# Altium schematic sheet UART.SchDoc
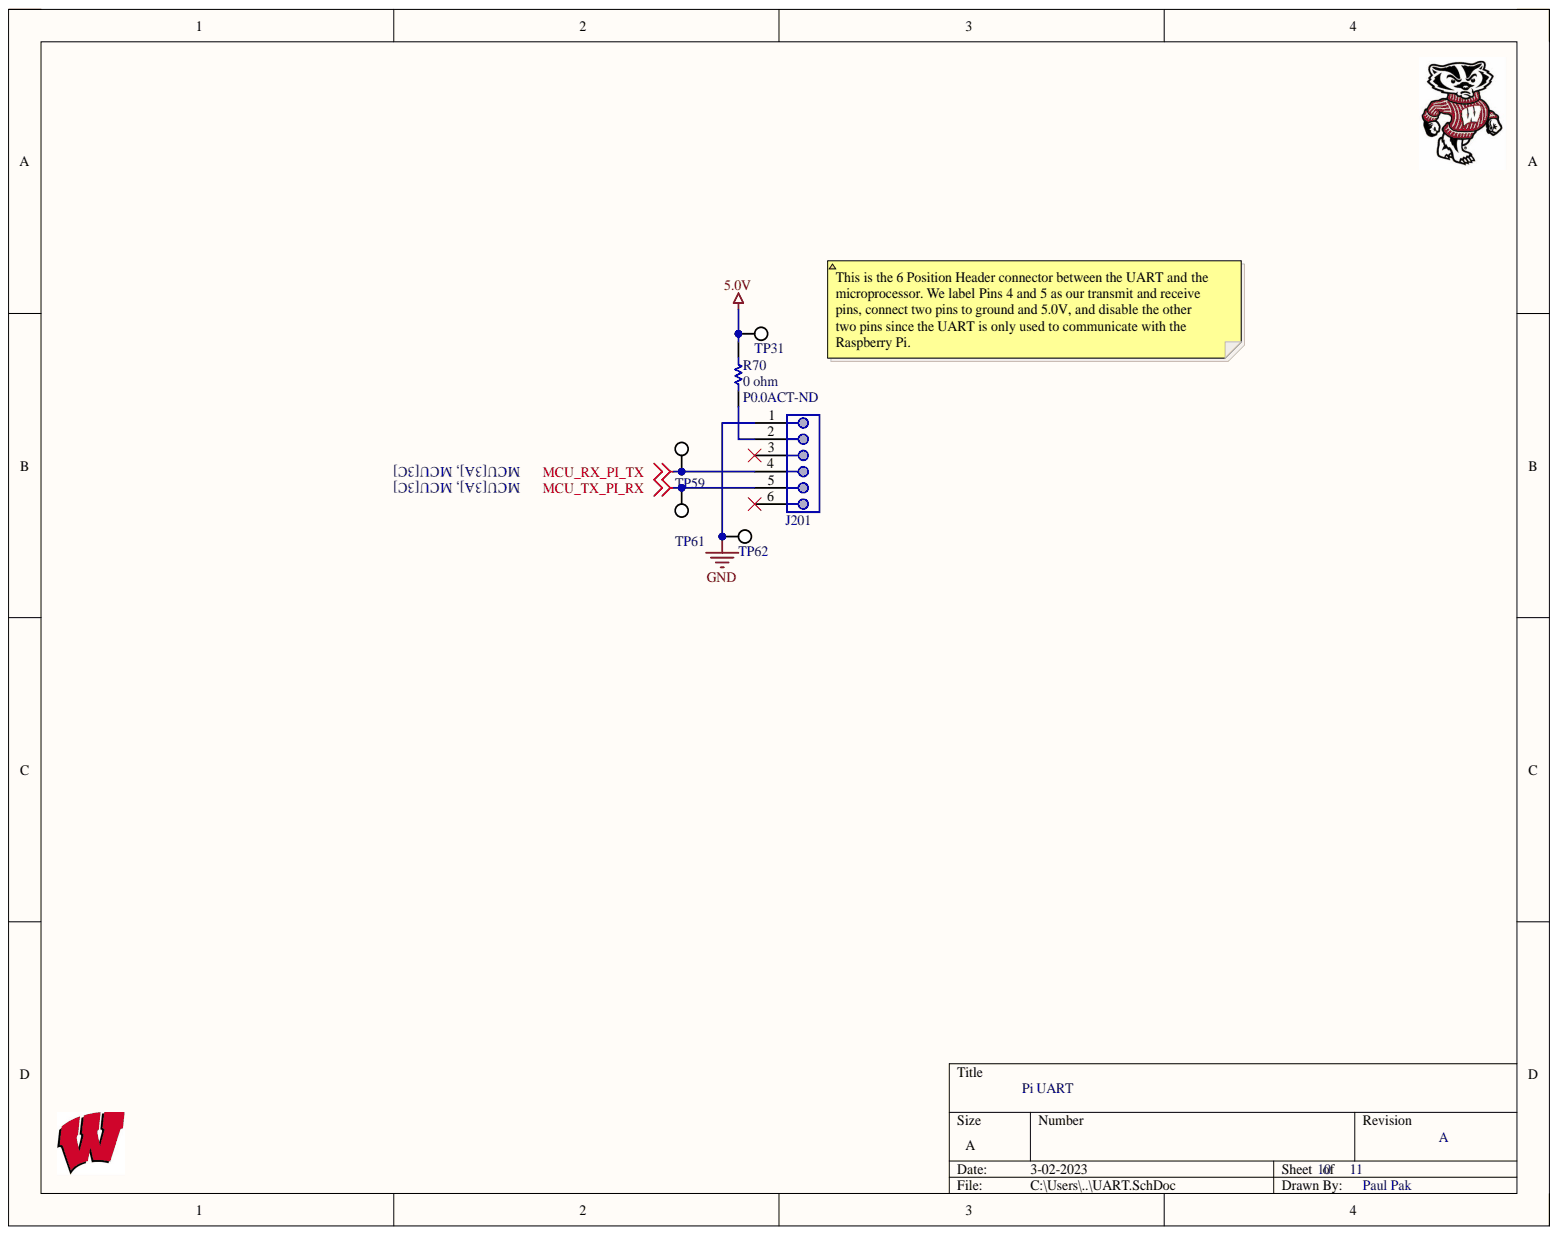
[diagram p0: UART.SchDoc]

## Parts
J201  (no value)  [HDR1X6]
R70  0 ohm  Supplier Part Number 1: P0.0ACT-ND  [RESC2013X70X40NL20T20]
TP31  5019  [KSTN-5019_V]  (value not drawn)
TP59  5019  [KSTN-5019_V]  (value not drawn)
TP61  5019  [KSTN-5019_V]  (value not drawn)
TP62  5019  [KSTN-5019_V]  (value not drawn)

## Nets
5.0V: R70.2 TP31.1
GND: J201.1 TP62.1
MCU_RX_PI_TX: J201.4 TP59.1
MCU_TX_PI_RX: J201.5 TP61.1
NetJ201_2: J201.2 R70.1

Not connected: J201 3 6

## Library data of the parts
J201 = CONN_HEADER_MALE_1x6: Female, 6C, Rt Angle, .100"CC; 3.15mm Tail, No Mounting; PBT, Phos. Bronze, 105 C; Manufacturer 1: Sullins; Manufacturer Part Number 1: PPPC061LGBN-RC; Connector Type: Header; Number of Positions: 6; Pitch: 0.1inch; Category: Connectors; Color: Black; Component Kind: Standard; ComponentLink1Description: https://media.digikey.com/pdf/Data%20Sheets/Sullins%20PDFs/Female_Headers.100_DS.pdf?src-supplier=Digi-Key; ComponentLink1URL: https://media.digikey.com/pdf/Data%20Sheets/Sullins%20PDFs/Female_Headers.100_DS.pdf?src-supplier=Digi-Key; ComponentLink2Description: http://www.sullinscorp.com/catalogs/78_Page80-81_HEADER_.100_2.54mm_FEMALE.pdf?src-supplier=Future+Electronics; ComponentLink2URL: http://www.sullinscorp.com/catalogs/78_Page80-81_HEADER_.100_2.54mm_FEMALE.pdf?src-supplier=Future+Electronics; Contact Material: Bronze; Contact Plating: Gold; Contact Type: Female Socket; Height: 2.4mm; Housing Color: Black; Housing Material: Plastic; Lead Free: Lead Free; Length: 15.7mm; Library Name: Connectors.SchLib; Mount: Through Hole; Number of Rows: 1; Package Quantity: 1000; Packaging: Bulk; Pricing 1: 1=0.66000, 10=0.56000, 80=0.50288, 440=0.41148, 1000=0.36576, 5000=0.33020 (USD); RoHS: Yes; RoHS Compliant: Yes; Stock 1: 30480; Supplier 1: Digi-Key; Supplier Part Number 1: S5481-ND; Termination: Solder; Width: 8.5mm
R70 = CMP-1665-00002-5: Chip Resistor, 0 Ohm, +/- 1%, 0.125 W, -55 to 155 degC, 0805 (2012 Metric), RoHS, Tape and Reel; Manufacturer: Panasonic; Manufacturer Part Number: ERJ-6GEY0R00V; Current Rating: 2A; Max Power Dissipation: 125mW; Temperature Coefficient: 600ppm/°C; Tolerance: 5%; Value: 0R; Voltage Rating: 150V; Case Code (Imperial): 0805; Case Code (Metric): 2012; Case/Package: 0805; Category: Chip SMD Resistors; Component Kind: Standard; ComponentLink1Description: Manufacturer URL; ComponentLink1URL: http://www.panasonic.com/; ComponentLink2Description: Datasheet; ComponentLink2URL: http://industrial.panasonic.com/cdbs/www-data/pdf/RDA0000/AOA0000C84.pdf; Construction: Rectangular; Contact Plating: Tin; DatasheetVersion: 03/2015; Depth: 1.25mm; Features: Automotive AEC-Q200; Height: 0.6 mm; Lead Free: Lead Free; Length: 2 mm; Library Name: Altium Content Vault; Max Operating Temperature: 155 degC; Military Standard: Not; Min Operating Temperature: -55 degC; Mounting Technology: Surface Mount; Number of Terminations: 2; Package Quantity: 5000; PackageDescription: 2-Pin Surface Mount Device, Body 2 x 1.25 mm; Packaging: Tape and Reel; PartNumber: ERJ-6GEY0R00V; Power Rating: 125mW; Pricing 1: 1=0.10000, 10=0.06400, 25=0.04680, 50=0.03560, 100=0.02620, 250=0.02000, 500=0.01598, 1000=0.01177 (USD); Radiation Hardening: No; Ratings: AEC-Q200; REACH SVHC: No SVHC; Reach SVHC Compliant: Yes; Resistance: 0 Ohm; Resistor Type: Thick Film; RoHS: Yes; RoHS Compliant: Yes; RoHSCompliant: True; Series: ERJ; Stock 1: 3172332; Supplier 1: Digi-Key; Technology: THICK FILM; Termination: SMD/SMT; Voltage Rating (DC): 150V; Width: 1.25 mm
TP31 TP59 TP61 TP62 = CMP-1672-00008-1: Test Point, 1 Position SMD, RoHS, Tape and Reel; Manufacturer: Keystone Electronics; Body Material: Bronze; Plating: Silver; Category: Connectors; Component Kind: Standard; ComponentLink1Description: Manufacturer URL; ComponentLink1URL: http://www.keyelco.com/; ComponentLink2Description: Datasheet; ComponentLink2URL: http://www.keyelco.com/product-pdf.cfm?p=1357; ComponentLink3Description: https://datasheet.ciiva.com/16885/70182998-16885945.pdf?src-supplier=Allied+Electronics; ComponentLink3URL: https://datasheet.ciiva.com/16885/70182998-16885945.pdf?src-supplier=Allied+Electronics; ComponentLink4Description: https://datasheet.ciiva.com/14848/m60-2p61-14848437.pdf?src-supplier=Digi-Key; ComponentLink4URL: https://datasheet.ciiva.com/14848/m60-2p61-14848437.pdf?src-supplier=Digi-Key; ComponentLink5Description: https://datasheet.ciiva.com/14848/m60-2p61-14848438.pdf?src-supplier=Digi-Key; ComponentLink5URL: https://datasheet.ciiva.com/14848/m60-2p61-14848438.pdf?src-supplier=Digi-Key; ComponentLink6Description: https://datasheet.ciiva.com/14848/m60-2p61-14848439.pdf?src-supplier=Digi-Key; ComponentLink6URL: https://datasheet.ciiva.com/14848/m60-2p61-14848439.pdf?src-supplier=Digi-Key; ComponentLink7Description: https://datasheet.ciiva.com/16318/m60-2p61-16318395.pdf?src-supplier=Future+Electronics; ComponentLink7URL: https://datasheet.ciiva.com/16318/m60-2p61-16318395.pdf?src-supplier=Future+Electronics; Contact Material: Bronze; Contact Plating: Silver; DatasheetVersion: 03/2014; Height: 0.09inch; Lead Free: Lead Free; Library Name: Altium Content Vault; Manufacturer Part Number: 5019; Material: Bronze; Mount: Surface Mount; Mounting Technology: Surface Mount; Number of Pins: 1; Package Quantity: 1000; PackageDescription: 1-Pin Surface Mount Device, Body 3.81 x 2.03 mm; PackageReference: 5019; Packaging: Tape and Reel; Pins: 1; Pricing 1: 1=0.34000, 25=0.27360, 100=0.22340, 250=0.20064, 500=0.19152 (USD); Reach SVHC Compliant: Yes; RoHS: Yes; RoHS Compliant: Yes; RoHSCompliant: True; Series: 5019; Stock 1: 1009631; Supplier 1: Digi-Key; Supplier Part Number 1: 36-5019CT-ND; Termination: SMD/SMT
